# Altium schematic sheet Relay.SchDoc
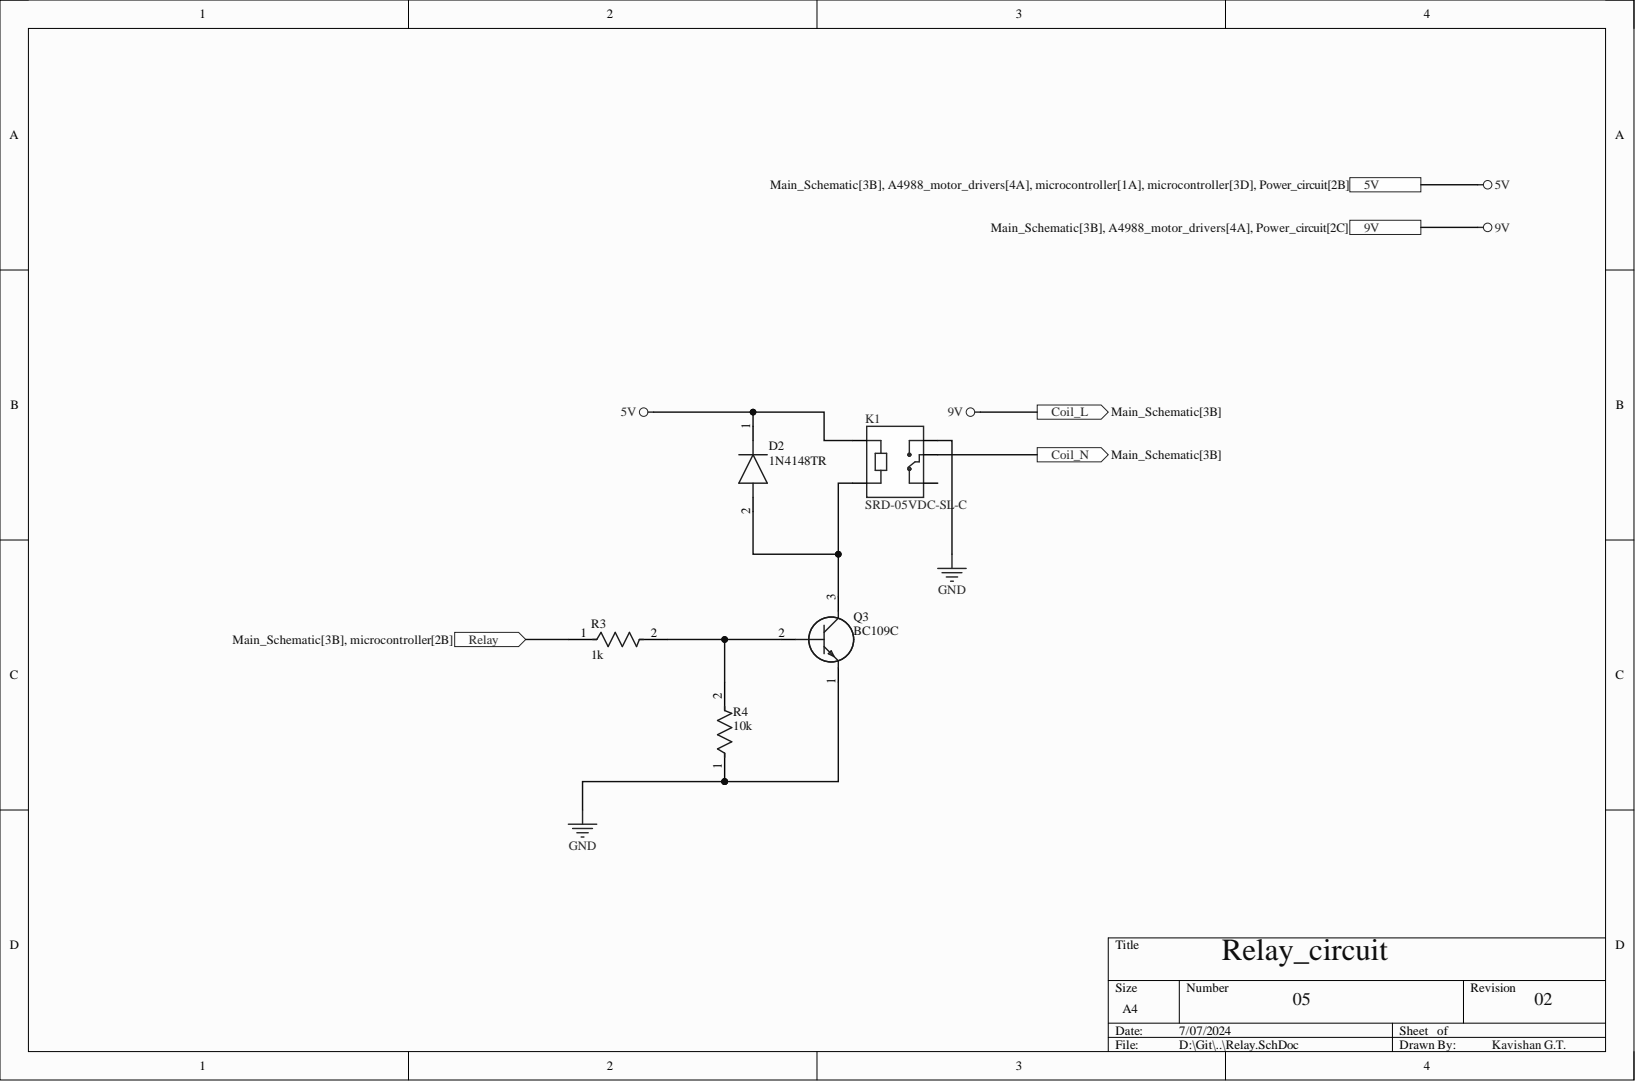
[diagram p0: Relay.SchDoc]

## Parts
D2  1N4148TR  [DIOAD990W55L340D162]
K1  SRD-05VDC-SL-C  [RELAY_SRD-05VDC-SL-C]
Q3  BC109C  [CENTRAL_TO18]
R3  1k  [FP-MFR-25-MFG]
R4  10k  [FP-MFR-25-MFG]

## Ports
5V, 9V, Coil_L, Coil_N, Relay

## Nets
GND: K1.NO Q3.1 R4.1
5V: D2.1 K1.A1 ; port 5V
Coil_N: K1.COM ; port Coil_N
Relay: R3.1 ; port Relay
NetD2_2: D2.2 K1.A2 Q3.3
NetQ3_2: Q3.2 R3.2 R4.2
9V: ; port 9V, port Coil_L

Not connected: K1 NC

## Library data of the parts
D2 = 1N4148TR: Diode; Manufacturer_Name: Vishay; Arrow Part Number: 1N4148TR; Arrow Price/Stock: https://www.arrow.com/en/products/1n4148tr/vishay?region=nac; Component Kind: Standard; Datasheet Link: https://www.vishay.com/docs/81857/1n4148.pdf; Manufacturer_Part_Number: 1N4148TR; Mouser Part Number: 78-1N4148; Mouser Price/Stock: https://www.mouser.co.uk/ProductDetail/Vishay-Semiconductors/1N4148TR?qs=HfDODkYFUverVKi%2FJ%2Fe5IA%3D%3D
K1 = SRD-05VDC-SL-C: 5V Trigger Relay Module For Arduino And Raspberry Pi 5V Trigger Relay Module For Arduino And Raspberry Pi; MF: Songle Relay; Availability: Not in stock; Check_prices: https://www.snapeda.com/parts/SRD-05VDC-SL-C/Songle+Relay/view-part/?ref=eda; Component Kind: Standard; Library Name: SRD-05VDC-SL-C.IntLib; MP: SRD-05VDC-SL-C; Package: NON STANDARD-5 Songle Relay; Price: None; SnapEDA_Link: https://www.snapeda.com/parts/SRD-05VDC-SL-C/Songle+Relay/view-part/?ref=snap
Q3 = BC109C: Transistor BJT NPN; Manufacturer_Name: Central Semiconductor; Component Kind: Standard; Datasheet Link: https://my.centralsemi.com/get_document.php?cmp=1&mergetype=pd&mergepath=pd&pdf_id=BC107.PDF; Manufacturer_Part_Number: BC109C; Mouser Part Number: 610-BC109C; Mouser Price/Stock: https://www.mouser.com/Search/Refine.aspx?Keyword=610-BC109C
R3 R4 = CMP-03413-000001-1: RES 1.18K OHM 1/4W 1% AXIAL; Manufacturer: Yageo; Manufacturer Part Number: MFR-25FBF52-1K18; Power Rating: 0.25W; Resistance: 1.18kΩ; Temperature Coefficient: 100ppm/°C; Value: 1.18kΩ; Voltage Rating: 250V; Automotive: No; Category: Res; Component Kind: Standard; ComponentLink2Description: Datasheet; ComponentLink2URL: https://www.yageo.com/upload/media/product/products/datasheet/lr/Yageo_LR_MFR_1.pdf; Composition: Metal Film; Device Class L1: Passive Components; Device Class L2: Resistors; Device Class L3: Through-Hole Resistors; Digikey Description: RES 1.18K OHM 1/4W 1% AXIAL; Height: 2.6mm; Ipc Land Pattern Name: RESAD1000W75L680D260; Lead Free: Yes; Library Name: Altium Content Vault; Mouser Description: Metal Film Resistors 1.18K OHM 1/4W 1%; Octopart: https://octopart.com/mfr-25fbf52-1k18-yageo-56251681; Package: MFR-25; RoHS: TRUE; Series: MFR; Temperature: -55°C to +155°C; Temperature Range High: +155°C; Temperature Range Low: -55°C; Tolerance: 1%
